# Altium schematic sheet GNSS_Workshop.SchDoc
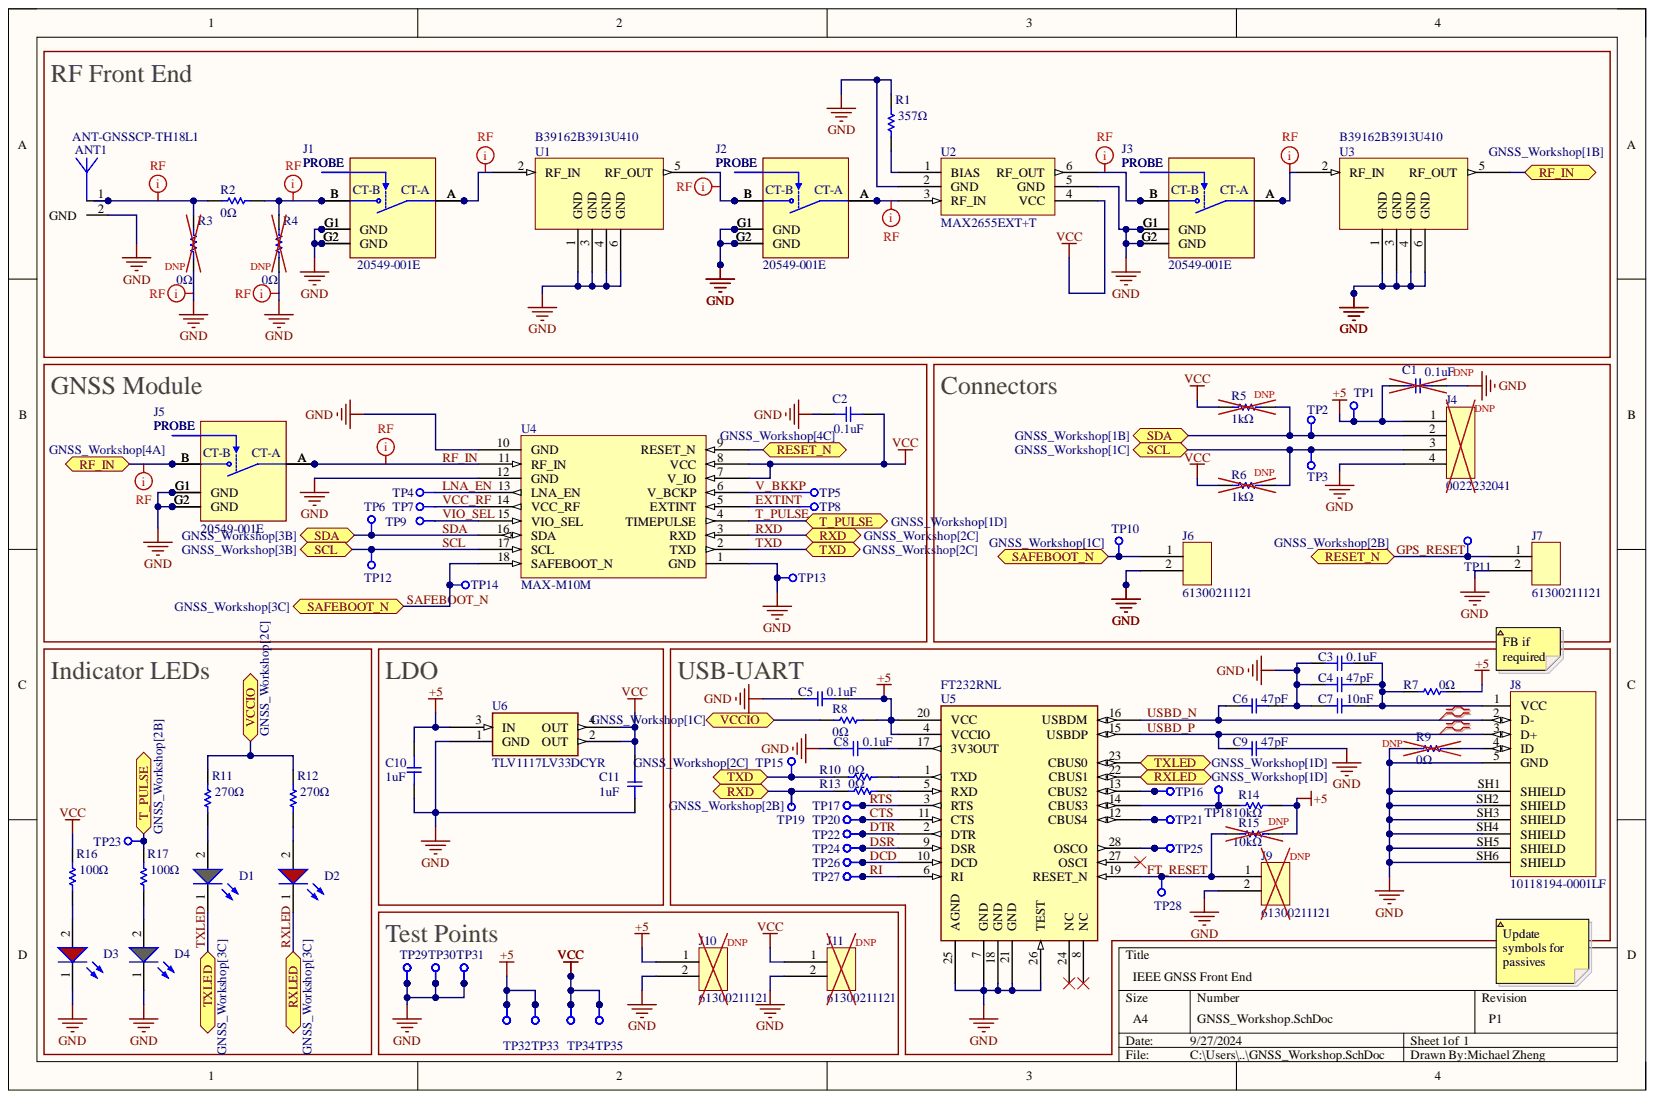
[diagram p0: GNSS_Workshop.SchDoc]

## Parts
ANT1  ANT-GNSSCP-TH18L1  [ANT-GNSSCP-TH18L1]
C1  Capacitance: 0.1uF  [CAP_MURATA_0603]  (hidden comment: GCM188L81H104KA57D)
C2  Capacitance: 0.1uF  [CAP_MURATA_0603]  (hidden comment: GCM188L81H104KA57D)
C3  Capacitance: 0.1uF  [CAP_MURATA_0603]  (hidden comment: GCM188L81H104KA57D)
C4  Capacitance: 47pF  [CAP_MURATA_0603]  (hidden comment: GCM188L81H104KA57D)
C5  Capacitance: 0.1uF  [CAP_MURATA_0603]  (hidden comment: GCM188L81H104KA57D)
C6  Capacitance: 47pF  [CAP_MURATA_0603]  (hidden comment: GCM188L81H104KA57D)
C7  Capacitance: 10nF  [CAP_MURATA_0603]  (hidden comment: GCM188L81H104KA57D)
C8  Capacitance: 0.1uF  [CAP_MURATA_0603]  (hidden comment: GCM188L81H104KA57D)
C9  Capacitance: 47pF  [CAP_MURATA_0603]  (hidden comment: GCM188L81H104KA57D)
C10  Capacitance: 1uF  [CAP_MURATA_0603]  (hidden comment: GRM188R61A105KA61D)
C11  Capacitance: 1uF  [CAP_MURATA_0603]  (hidden comment: GRM188R61A105KA61D)
D1  Green, 3.2V, 140°  [WL-SMCW - duplicate]  (value not drawn)
D2  Red, 2V, 140°  [WL-SMCW - duplicate]  (value not drawn)
D3  Red, 2V, 140°  [WL-SMCW - duplicate]  (value not drawn)
D4  Green, 3.2V, 140°  [WL-SMCW - duplicate]  (value not drawn)
J1  20549-001E  [IPEX_20549-001E]
J2  20549-001E  [IPEX_20549-001E]
J3  20549-001E  [IPEX_20549-001E]
J4  0022232041  [CONN HEADER VERT 4POS 2.54MM]
J5  20549-001E  [IPEX_20549-001E]
J6  61300211121  [61300211121]
J7  61300211121  [61300211121]
J8  10118194-0001LF  [AMPHENOL_10118194-0001LF]
J9  61300211121  [61300211121]
J10  61300211121  [61300211121]
J11  61300211121  [61300211121]
R1  Resistance: 357Ω  [RC0603JR-070RL]  (hidden comment: RC0603JR-070RL)
R2  Resistance: 0Ω  [RC0603JR-070RL]  (hidden comment: RC0603JR-070RL)
R3  Resistance: 0Ω  [RC0603JR-070RL]  (hidden comment: RC0603JR-070RL)
R4  Resistance: 0Ω  [RC0603JR-070RL]  (hidden comment: RC0603JR-070RL)
R5  Resistance: 1kΩ  [RC0603JR-070RL]  (hidden comment: RC0603JR-070RL)
R6  Resistance: 1kΩ  [RC0603JR-070RL]  (hidden comment: RC0603JR-070RL)
R7  Resistance: 0Ω  [RC0603JR-070RL]  (hidden comment: RC0603JR-070RL)
R8  Resistance: 0Ω  [RC0603JR-070RL]  (hidden comment: RC0603JR-070RL)
R9  Resistance: 0Ω  [RC0603JR-070RL]  (hidden comment: RC0603JR-070RL)
R10  Resistance: 0Ω  [RC0603JR-070RL]  (hidden comment: RC0603JR-070RL)
R11  Resistance: 270Ω  [RC0603JR-070RL]  (hidden comment: RC0603JR-070RL)
R12  Resistance: 270Ω  [RC0603JR-070RL]  (hidden comment: RC0603JR-070RL)
R13  Resistance: 0Ω  [RC0603JR-070RL]  (hidden comment: RC0603JR-070RL)
R14  RC0603JR-070RL  [RC0603JR-070RL]  (value not drawn)
R15  Resistance: 10kΩ  [RC0603JR-070RL]  (hidden comment: RC0603JR-070RL)
R16  Resistance: 100Ω  [RC0603JR-070RL]  (hidden comment: RC0603JR-070RL)
R17  Resistance: 100Ω  [RC0603JR-070RL]  (hidden comment: RC0603JR-070RL)
TP1  TEST_POINT  [TEST_POINT]  (value not drawn)
TP2  TEST_POINT  [TEST_POINT]  (value not drawn)
TP3  TEST_POINT  [TEST_POINT]  (value not drawn)
TP4  TEST_POINT  [TEST_POINT]  (value not drawn)
TP5  TEST_POINT  [TEST_POINT]  (value not drawn)
TP6  TEST_POINT  [TEST_POINT]  (value not drawn)
TP7  TEST_POINT  [TEST_POINT]  (value not drawn)
TP8  TEST_POINT  [TEST_POINT]  (value not drawn)
TP9  TEST_POINT  [TEST_POINT]  (value not drawn)
TP10  TEST_POINT  [TEST_POINT]  (value not drawn)
TP11  TEST_POINT  [TEST_POINT]  (value not drawn)
TP12  TEST_POINT  [TEST_POINT]  (value not drawn)
TP13  TEST_POINT  [TEST_POINT]  (value not drawn)
TP14  TEST_POINT  [TEST_POINT]  (value not drawn)
TP15  TEST_POINT  [TEST_POINT]  (value not drawn)
TP16  TEST_POINT  [TEST_POINT]  (value not drawn)
TP17  TEST_POINT  [TEST_POINT]  (value not drawn)
TP18  TEST_POINT  [TEST_POINT]  (value not drawn)
TP19  TEST_POINT  [TEST_POINT]  (value not drawn)
TP20  TEST_POINT  [TEST_POINT]  (value not drawn)
TP21  TEST_POINT  [TEST_POINT]  (value not drawn)
TP22  TEST_POINT  [TEST_POINT]  (value not drawn)
TP23  TEST_POINT  [TEST_POINT]  (value not drawn)
TP24  TEST_POINT  [TEST_POINT]  (value not drawn)
TP25  TEST_POINT  [TEST_POINT]  (value not drawn)
TP26  TEST_POINT  [TEST_POINT]  (value not drawn)
TP27  TEST_POINT  [TEST_POINT]  (value not drawn)
TP28  TEST_POINT  [TEST_POINT]  (value not drawn)
TP29  TEST_POINT  [TEST_POINT]  (value not drawn)
TP30  TEST_POINT  [TEST_POINT]  (value not drawn)
TP31  TEST_POINT  [TEST_POINT]  (value not drawn)
TP32  TEST_POINT  [TEST_POINT]  (value not drawn)
TP33  TEST_POINT  [TEST_POINT]  (value not drawn)
TP34  TEST_POINT  [TEST_POINT]  (value not drawn)
TP35  TEST_POINT  [TEST_POINT]  (value not drawn)
U1  B39162B3913U410  [B39162B3913U410]
U2  MAX2655EXT+T  [MAX2655EXT+T]
U3  B39162B3913U410  [B39162B3913U410]
U4  MAX-M10M  [MAX-M10M]
U5  FT232RNL  [FT232RNL]
U6  TLV1117LV33DCYR  [TLV1117LV33DCYR]

## Ports
RESET_N, RF_IN, RXD, RXLED, SAFEBOOT_N, SCL, SDA, T_PULSE, TXD, TXLED, VCCIO

## Nets
+5: C1.1 C5.2 C10.2 J4.1 J10.1 R7.2 R8.1 R14.2 R15.2 TP1.1 TP32.1 TP33.1 U5.4(VCCIO) U5.20(VCC) U6.3(IN)
GND: ANT1.2 C1.2 C2.1 C3.2 C4.2 C5.1 C6.1 C7.2 C8.2 C9.1 C10.1 C11.1 D3.1 D4.1 J1.G1(GND) J1.G2(GND) J2.G1(GND) J2.G2(GND) J3.G1(GND) J3.G2(GND) J4.4 J5.G1(GND) J5.G2(GND) J6.2 J7.2 J8.5(GND) J8.SH1(SHIELD) J8.SH2(SHIELD) J8.SH3(SHIELD) J8.SH4(SHIELD) J8.SH5(SHIELD) J8.SH6(SHIELD) J9.2 J10.2 J11.2 R1.2 R3.1 R4.1 R9.2 TP13.1 TP29.1 TP30.1 TP31.1 U1.1(GND) U1.3(GND) U1.4(GND) U1.6(GND) U2.2(GND) U2.5(GND) U3.1(GND) U3.3(GND) U3.4(GND) U3.6(GND) U4.1(GND) U4.10(GND) U4.12(GND) U5.7(GND) U5.18(GND) U5.21(GND) U5.25(AGND) U5.26(TEST) U6.1(GND)
VCC: C2.2 C11.2 J11.1 R5.2 R6.2 R16.2 TP34.1 TP35.1 U2.4(VCC) U4.7(V_IO) U4.8(VCC) U6.2(OUT) U6.4(OUT)
CTS: TP20.1 U5.11(CTS)
DCD: TP26.1 U5.10(DCD)
DSR: TP24.1 U5.9(DSR)
DTR: TP22.1 U5.2(DTR)
EXTINT: TP8.1 U4.5(EXTINT)
FT_RESET: J9.1 R15.1 TP28.1 U5.19(RESET_N)
GPS_RESET: J7.1 TP11.1 U4.9(RESET_N) ; port RESET_N
LNA_EN: TP4.1 U4.13(LNA_EN)
RF_IN: J5.A U4.11(RF_IN)
RI: TP27.1 U5.6(RI)
RTS: TP17.1 U5.3(RTS)
RXD: R13.1 TP19.1 U4.3(RXD) ; port RXD
RXLED: D2.1 U5.22(CBUS1) ; port RXLED
SAFEBOOT_N: J6.1 TP10.1 TP14.1 U4.18(SAFEBOOT_N) ; port SAFEBOOT_N
SCL: J4.3 R6.1 TP3.1 TP12.1 U4.17(SCL) ; port SCL
SDA: J4.2 R5.1 TP2.1 TP6.1 U4.16(SDA) ; port SDA
T_PULSE: R17.2 TP23.1 U4.4(TIMEPULSE) ; port T_PULSE
TXD: R10.1 TP15.1 U4.2(TXD) ; port TXD
TXLED: D1.1 U5.23(CBUS0) ; port TXLED
USBD_N: C6.2 J8.2(D-) U5.16(USBDM)
USBD_P: C9.2 J8.3(D+) U5.15(USBDP)
V_BKKP: TP5.1 U4.6(V_BCKP)
VCC_RF: TP7.1 U4.14(VCC_RF)
VCCIO: R8.2 R11.2 R12.2 ; port VCCIO
VIO_SEL: TP9.1 U4.15(VIO_SEL)
NetANT1_1: ANT1.1 R2.2 R3.2
NetC3_1: C3.1 C4.1 C7.1 J8.1(VCC) R7.1
NetC8_1: C8.1 U5.17(3V3OUT)
NetD1_2: D1.2 R11.1
NetD2_2: D2.2 R12.1
NetD3_2: D3.2 R16.1
NetD4_2: D4.2 R17.1
NetJ1_A: J1.A U1.2(RF_IN)
NetJ1_B: J1.B R2.1 R4.2
NetJ2_A: J2.A U2.3(RF_IN)
NetJ2_B: J2.B U1.5(RF_OUT)
NetJ3_A: J3.A U3.2(RF_IN)
NetJ3_B: J3.B U2.6(RF_OUT)
NetJ5_B: J5.B U3.5(RF_OUT) ; port RF_IN
NetJ8_4: J8.4(ID) R9.1
NetR1_1: R1.1 U2.1(BIAS)
NetR10_2: R10.2 U5.1(TXD)
NetR13_2: R13.2 U5.5(RXD)
NetR14_1: R14.1 TP18.1 U5.14(CBUS3)
NetTP16_1: TP16.1 U5.13(CBUS2)
NetTP21_1: TP21.1 U5.12(CBUS4)
NetTP25_1: TP25.1 U5.28(OSCO)

Not connected: U5 8(NC) 24(NC) 27(OSCI)

## Library data of the parts
ANT1 = ANT-GNSSCP-TH18L1: CERAMIC PATCH GNSS ANTENNA; Component Kind: Standard; Library Name: Symbols.SchLib
C1-C9 = GCM188L81H104KA57D: CAP CER 0.1UF 50V X8L 0603; Component Kind: Standard; Library Name: Symbols.SchLib
C10 C11 = GRM188R61A105KA61D: CAP CER 1UF 10V X5R 0603; Component Kind: Standard; Library Name: Symbols.SchLib
D1 D4 = 150060GS75000: WL-SMCW Mono-color Chip LED Waterclear, SMT, size 0603, Green, 3.2V, 140°; Manufacturer: Wurth Elektronik; Case/Size Code: 0603; Chip Technology: InGaN; Dominant Wavelength: 525nm; Luminous Intensity: 430mcd; Peak Wavelength: 515nm; Category: Signal LEDs; Component Kind: Standard; ComponentLink1Description: Website Link; ComponentLink1URL: https://www.we-online.com/redexpert/article/150060GS75000?ad; ComponentLink2Description: Datasheet Link; ComponentLink2URL: https://www.we-online.com/redexpert/spec/150060GS75000?ad; Emitting Color: Green; Forward Voltage: 3.2V; Height: 0.7mm; Length: 1.6mm; Library Name: Symbols.SchLib; Manufacturer Part Number: 150060GS75000; Match Code: WL-SMCW; Mount: Surface Mount; Operating Temperature Max: 85°C; Operating Temperature Min: -40°C; Packaging: Tape and Reel; Viewing Angle: 140°; Width: 0.8mm
D2 D3 = 150060RS75000: WL-SMCW Mono-color Chip LED Waterclear, SMT, size 0603, Red, 2V, 140°; Manufacturer: Wurth Elektronik; Case/Size Code: 0603; Chip Technology: AlInGaP; Dominant Wavelength: 625nm; Luminous Intensity: 250mcd; Peak Wavelength: 630nm; Category: Signal LEDs; Component Kind: Standard; ComponentLink1Description: Website Link; ComponentLink1URL: https://www.we-online.com/redexpert/article/150060RS75000?ad; ComponentLink2Description: Datasheet Link; ComponentLink2URL: https://www.we-online.com/redexpert/spec/150060RS75000?ad; Emitting Color: Red; Forward Voltage: 2V; Height: 0.7mm; Length: 1.6mm; Library Name: Symbols.SchLib; Manufacturer Part Number: 150060RS75000; Match Code: WL-SMCW; Mount: Surface Mount; Operating Temperature Max: 85°C; Operating Temperature Min: -40°C; Packaging: Tape and Reel; Viewing Angle: 140°; Width: 0.8mm
J1-J3 J5 = 20549-001E: 1 POS Vertical SMD Coaxial RF switch connector with a 2.3 x 2.3 mm footprint and support to 11 GHz, MHC; MF: I-PEX; Availability: Warning; Check_prices: https://www.snapeda.com/parts/20549-001E/I-PEX/view-part/3220455/?ref=eda; Component Kind: Standard; Library Name: Symbols.SchLib; MP: 20549-001E; Package: None; Price: None; SnapEDA_Link: https://www.snapeda.com/parts/20549-001E/I-PEX/view-part/3220455/?ref=snap
J4 = 0022232041: CONN HEADER VERT 4POS 2.54MM; Component Kind: Standard; Library Name: Symbols.SchLib
J6 J7 J9-J11 = 61300211121: CONN HEADER VERT 2POS 2.54MM; Component Kind: Standard; Library Name: Symbols.SchLib
J8 = 10118194-0001LF: Micro USB, Input Output Connectors, B TYPE RECEPTACLE with flange; MF: Amphenol ICC; Check_prices: https://www.snapeda.com/parts/10118194-0001LF/Amphenol+FCI/view-part/?ref=eda; Component Kind: Standard; Library Name: Symbols.SchLib; MP: 10118194-0001LF; Package: None; SnapEDA_Link: https://www.snapeda.com/parts/10118194-0001LF/Amphenol+FCI/view-part/?ref=snap
R1-R13 R15-R17 = RC0603JR-070RL: Chip Resistor, 0 Ohm, +/- 5%, 0.1 W, -55 to 155 degC, 0603 (1608 Metric), RoHS, Tape and Reel; Manufacturer: Yageo; Power Rating: 100mW; Temperature Coefficient: 200ppm/degreesC; Voltage Rating: 50V; Case Code (Imperial): 0603; Case Code (Metric): 1608; Case/Package: 0603; Component Kind: Standard; Composition: ThickFilm; Contact Plating: Tin; Datasheet URL: http://www.yageo.com/NewPortal/yageodocoutput?fileName=/pdf/R-Chip/PYu-RC_Group_51_RoHS_L_5.pdf; Datasheet Version: Rev. 5, 10/2016; Depth: 0.8mm; Height: 0.45mm; Lead Free: LeadFree; Length: 1.6mm; Library Name: Symbols.SchLib; Manufacturer URL: http://www.yageo.com/; Max Operating Temperature: 155degC; Military Standard: Not; Min Operating Temperature: -55degC; Number of Pins: 2; Package Description: 2-Pin Surface Mount Device, Body 1.6 x 0.8 mm; Package Quantity: 5000; Package Reference: 0603; Packaging: TapeandReel; Radiation Hardening: No; REACH SVHC: NoSVHC; RoHSCompliant: true; Termination: SMD/SMT; Tolerance: 5%; Voltage Rating (DC): 50V; Width: 0.8mm
R14 = RC0603JR-070RL: Chip Resistor, 0 Ohm, +/- 5%, 0.1 W, -55 to 155 degC, 0603 (1608 Metric), RoHS, Tape and Reel; Manufacturer: Yageo; Power Rating: 100mW; Resistance: 10kΩ; Temperature Coefficient: 200ppm/degreesC; Voltage Rating: 50V; Case Code (Imperial): 0603; Case Code (Metric): 1608; Case/Package: 0603; Component Kind: Standard; Composition: ThickFilm; Contact Plating: Tin; Datasheet URL: http://www.yageo.com/NewPortal/yageodocoutput?fileName=/pdf/R-Chip/PYu-RC_Group_51_RoHS_L_5.pdf; Datasheet Version: Rev. 5, 10/2016; Depth: 0.8mm; Height: 0.45mm; Lead Free: LeadFree; Length: 1.6mm; Library Name: Symbols.SchLib; Manufacturer URL: http://www.yageo.com/; Max Operating Temperature: 155degC; Military Standard: Not; Min Operating Temperature: -55degC; Number of Pins: 2; Package Description: 2-Pin Surface Mount Device, Body 1.6 x 0.8 mm; Package Quantity: 5000; Package Reference: 0603; Packaging: TapeandReel; Radiation Hardening: No; REACH SVHC: NoSVHC; RoHSCompliant: true; Termination: SMD/SMT; Tolerance: 5%; Voltage Rating (DC): 50V; Width: 0.8mm
TP1-TP35 = TEST_POINT: TEST POINT 2MM; Component Kind: Standard; Library Name: Symbols.SchLib
U1 U3 = B39162B3913U410: FILTER SAW 1.588GHZ 6SMD; Component Kind: Standard; Library Name: Symbols.SchLib
U2 = MAX2655EXT+T: IC AMP GPS 1.4GHZ-1.7GHZ SC70-6; Component Kind: Standard; Library Name: Symbols.SchLib
U4 = MAX-M10M: GNSS LCC RX MOD, ROM, CRYSTAL; Component Kind: Standard; Library Name: Symbols.SchLib
U5 = FT232RNL: USB FULL SPEED TO SERIAL UART IC; Component Kind: Standard; Library Name: Symbols.SchLib
U6 = TLV1117LV33DCYR: IC REG LINEAR 3.3V 1A SOT223-4; Component Kind: Standard; Library Name: Symbols.SchLib
